# Altium schematic sheet yuanlitu.SchDoc
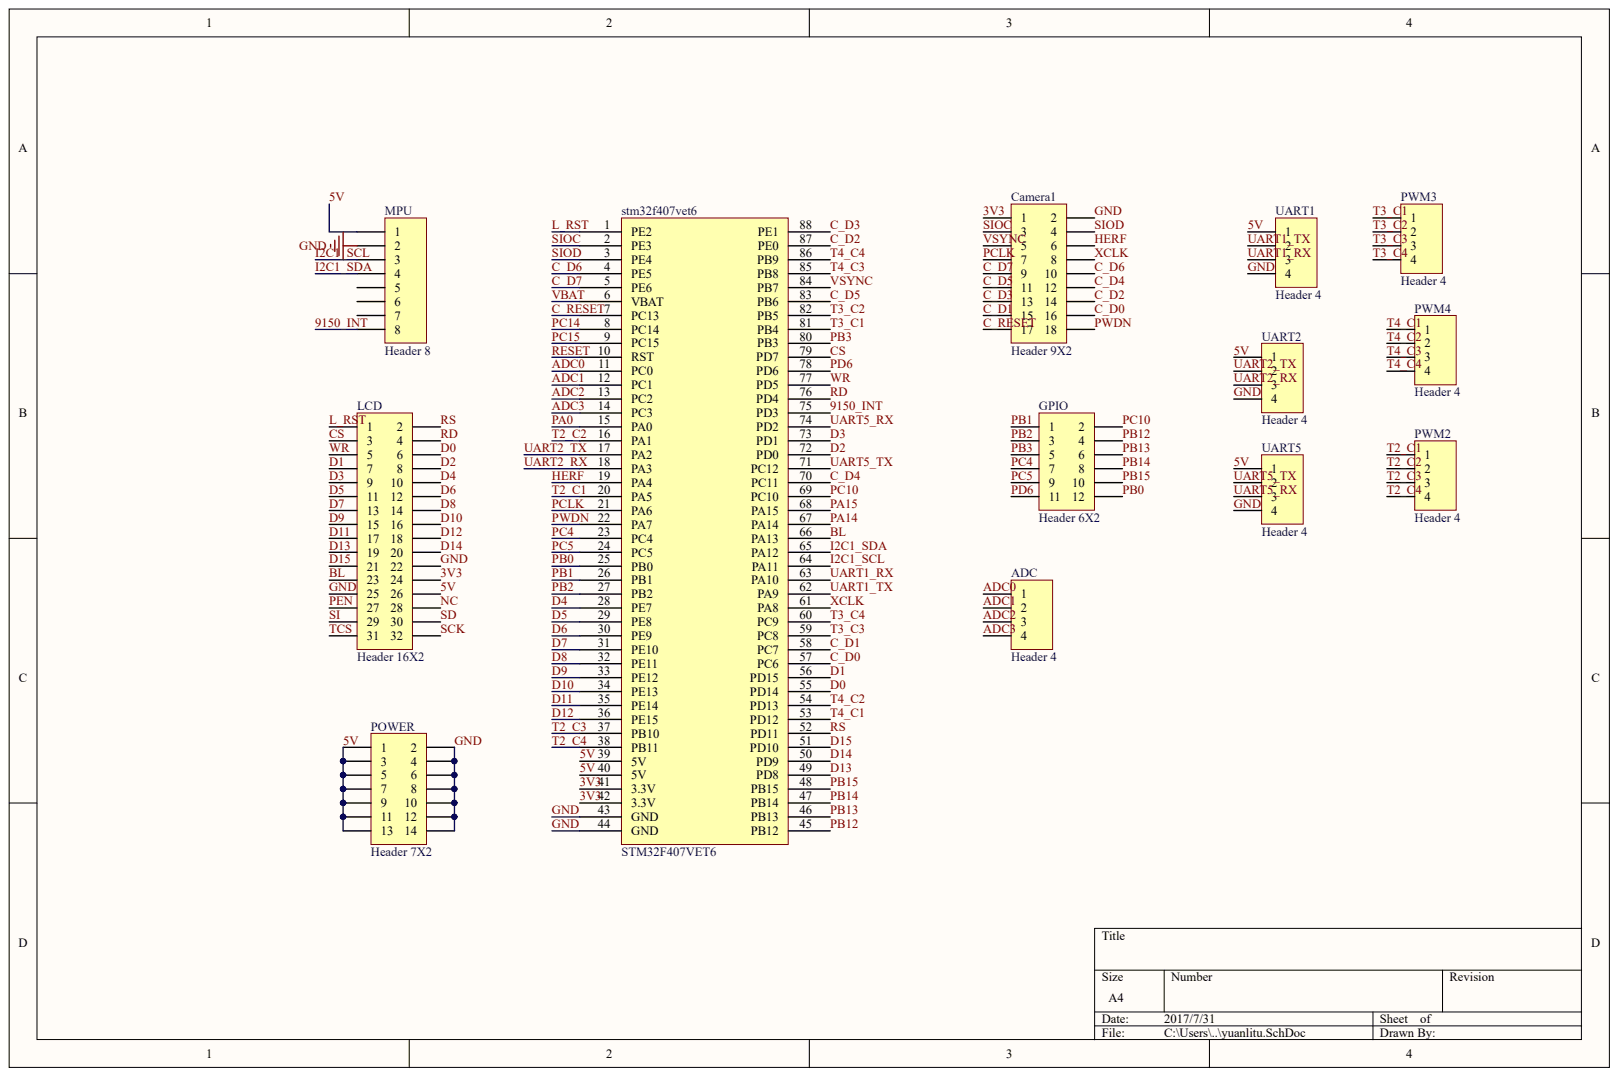
[diagram p0: yuanlitu.SchDoc]

## Parts
ADC  Header 4
Camera1  Header 9X2
GPIO  Header 6X2
LCD  Header 16X2
MPU  Header 8
POWER  Header 7X2
PWM2  Header 4
PWM3  Header 4
PWM4  Header 4
stm32f407vet6  STM32F407VET6
UART1  Header 4
UART2  Header 4
UART5  Header 4

## Nets
3V3: Camera1.1 LCD.24 stm32f407vet6.41(3.3V) stm32f407vet6.42(3.3V)
5V: LCD.26 MPU.1 POWER.1 POWER.3 POWER.5 POWER.7 POWER.9 POWER.11 POWER.13 stm32f407vet6.39(5V) stm32f407vet6.40(5V) UART1.1 UART2.1 UART5.1
9150_INT: MPU.8 stm32f407vet6.75(PD3)
ADC0: ADC.1 stm32f407vet6.11(PC0)
ADC1: ADC.2 stm32f407vet6.12(PC1)
ADC2: ADC.3 stm32f407vet6.13(PC2)
ADC3: ADC.4 stm32f407vet6.14(PC3)
BL: LCD.23 stm32f407vet6.66(PA13)
C_D0: Camera1.16 stm32f407vet6.57(PC6)
C_D1: Camera1.15 stm32f407vet6.58(PC7)
C_D2: Camera1.14 stm32f407vet6.87(PE0)
C_D3: Camera1.13 stm32f407vet6.88(PE1)
C_D4: Camera1.12 stm32f407vet6.70(PC11)
C_D5: Camera1.11 stm32f407vet6.83(PB6)
C_D6: Camera1.10 stm32f407vet6.4(PE5)
C_D7: Camera1.9 stm32f407vet6.5(PE6)
C_RESET: Camera1.17 stm32f407vet6.7(PC13)
CS: LCD.3 stm32f407vet6.79(PD7)
D0: LCD.6 stm32f407vet6.55(PD14)
D1: LCD.7 stm32f407vet6.56(PD15)
D2: LCD.8 stm32f407vet6.72(PD0)
D3: LCD.9 stm32f407vet6.73(PD1)
D4: LCD.10 stm32f407vet6.28(PE7)
D5: LCD.11 stm32f407vet6.29(PE8)
D6: LCD.12 stm32f407vet6.30(PE9)
D7: LCD.13 stm32f407vet6.31(PE10)
D8: LCD.14 stm32f407vet6.32(PE11)
D9: LCD.15 stm32f407vet6.33(PE12)
D10: LCD.16 stm32f407vet6.34(PE13)
D11: LCD.17 stm32f407vet6.35(PE14)
D12: LCD.18 stm32f407vet6.36(PE15)
D13: LCD.19 stm32f407vet6.49(PD8)
D14: LCD.20 stm32f407vet6.50(PD9)
D15: LCD.21 stm32f407vet6.51(PD10)
GND: Camera1.2 LCD.22 LCD.25 MPU.2 POWER.2 POWER.4 POWER.6 POWER.8 POWER.10 POWER.12 POWER.14 stm32f407vet6.43(GND) stm32f407vet6.44(GND) UART1.4 UART2.4 UART5.4
HERF: Camera1.6 stm32f407vet6.19(PA4)
I2C1_SCL: MPU.3 stm32f407vet6.64(PA11)
I2C1_SDA: MPU.4 stm32f407vet6.65(PA12)
L_RST: LCD.1 stm32f407vet6.1(PE2)
NC: LCD.28
PA0: stm32f407vet6.15(PA0)
PA14: stm32f407vet6.67(PA14)
PA15: stm32f407vet6.68(PA15)
PB0: GPIO.12 stm32f407vet6.25(PB0)
PB1: GPIO.1 stm32f407vet6.26(PB1)
PB2: GPIO.3 stm32f407vet6.27(PB2)
PB3: GPIO.5 stm32f407vet6.80(PB3)
PB12: GPIO.4 stm32f407vet6.45(PB12)
PB13: GPIO.6 stm32f407vet6.46(PB13)
PB14: GPIO.8 stm32f407vet6.47(PB14)
PB15: GPIO.10 stm32f407vet6.48(PB15)
PC4: GPIO.7 stm32f407vet6.23(PC4)
PC5: GPIO.9 stm32f407vet6.24(PC5)
PC10: GPIO.2 stm32f407vet6.69(PC10)
PC14: stm32f407vet6.8(PC14)
PC15: stm32f407vet6.9(PC15)
PCLK: Camera1.7 stm32f407vet6.21(PA6)
PD6: GPIO.11 stm32f407vet6.78(PD6)
PEN: LCD.27
PWDN: Camera1.18 stm32f407vet6.22(PA7)
RD: LCD.4 stm32f407vet6.76(PD4)
RESET: stm32f407vet6.10(RST)
RS: LCD.2 stm32f407vet6.52(PD11)
SCK: LCD.32
SD: LCD.30
SI: LCD.29
SIOC: Camera1.3 stm32f407vet6.2(PE3)
SIOD: Camera1.4 stm32f407vet6.3(PE4)
T2_C1: PWM2.1 stm32f407vet6.20(PA5)
T2_C2: PWM2.2 stm32f407vet6.16(PA1)
T2_C3: PWM2.3 stm32f407vet6.37(PB10)
T2_C4: PWM2.4 stm32f407vet6.38(PB11)
T3_C1: PWM3.1 stm32f407vet6.81(PB4)
T3_C2: PWM3.2 stm32f407vet6.82(PB5)
T3_C3: PWM3.3 stm32f407vet6.59(PC8)
T3_C4: PWM3.4 stm32f407vet6.60(PC9)
T4_C1: PWM4.1 stm32f407vet6.53(PD12)
T4_C2: PWM4.2 stm32f407vet6.54(PD13)
T4_C3: PWM4.3 stm32f407vet6.85(PB8)
T4_C4: PWM4.4 stm32f407vet6.86(PB9)
TCS: LCD.31
UART1_RX: stm32f407vet6.63(PA10) UART1.3
UART1_TX: stm32f407vet6.62(PA9) UART1.2
UART2_RX: stm32f407vet6.18(PA3) UART2.3
UART2_TX: stm32f407vet6.17(PA2) UART2.2
UART5_RX: stm32f407vet6.74(PD2) UART5.3
UART5_TX: stm32f407vet6.71(PC12) UART5.2
VBAT: stm32f407vet6.6(VBAT)
VSYNC: Camera1.5 stm32f407vet6.84(PB7)
WR: LCD.5 stm32f407vet6.77(PD5)
XCLK: Camera1.8 stm32f407vet6.61(PA8)

Not connected: MPU 5 6 7

## Library data of the parts
ADC Camera1 GPIO LCD MPU POWER PWM2-PWM4 stm32f407vet6 UART1 UART2 UART5 = (no symbol name)
  ADC Camera1 GPIO LCD MPU POWER PWM2-PWM4 UART1 UART2 UART5: LatestRevisionDate: 17-Jul-2002; LatestRevisionNote: Re-released for DXP Platform.; Publisher: Altium Limited
